# Altium schematic sheet InclinometerPower.SchDoc
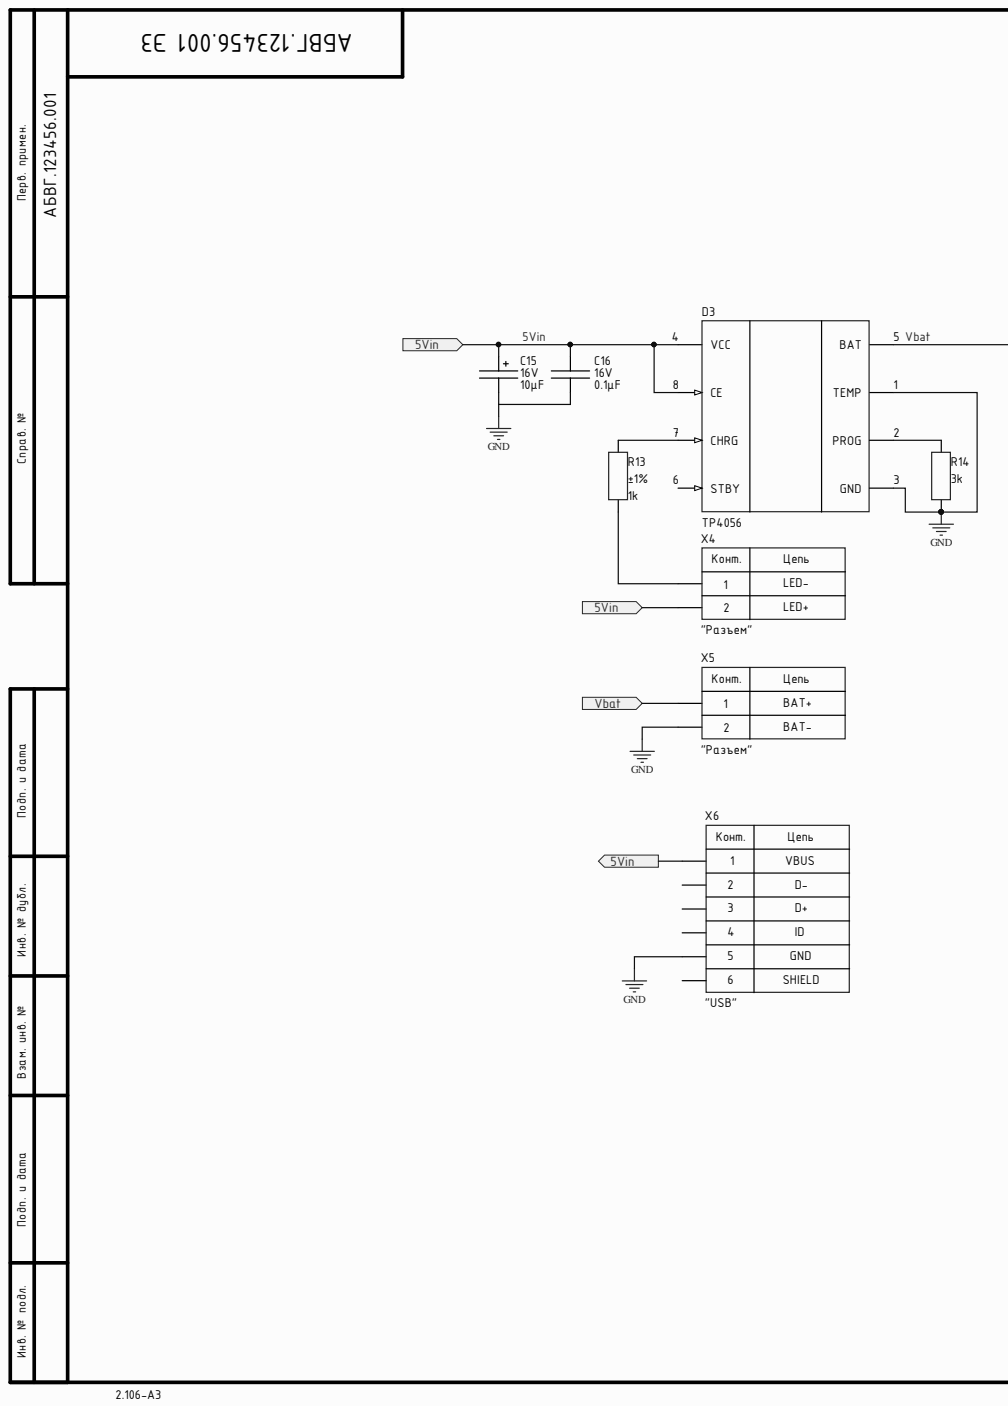
[diagram p0: InclinometerPower.SchDoc, left]
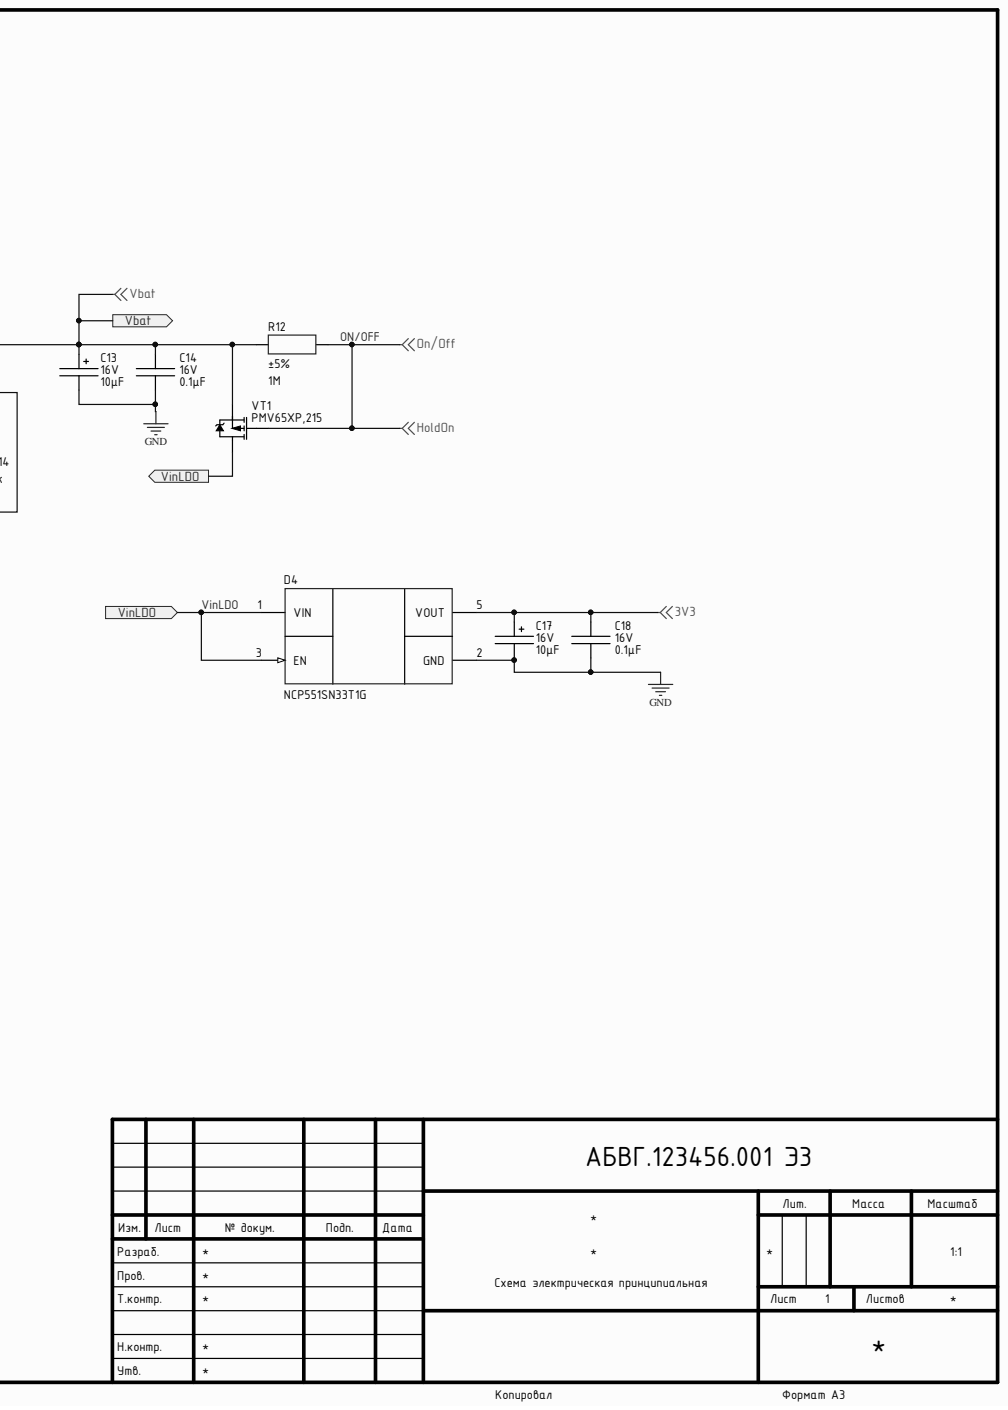
[diagram p1: InclinometerPower.SchDoc, right]

## Parts
C13  value 10μF  Voltage: 16V  [CAP: TANTAL-A]  (hidden comment: Tantalum capacitor, 10μF, 16V, ±10%, 3Ohm, case A (3216), -55...+125°C)
C14  value 0.1μF  Voltage: 16V  [CAP: 0603]  (hidden comment: Ceramic capacitor, 0.1μF, X7R, ±10%, 16V, 0603, -55...+125°C)
C15  value 10μF  Voltage: 16V  [CAP: TANTAL-A]  (hidden comment: Tantalum capacitor, 10μF, 16V, ±10%, 3Ohm, case A (3216), -55...+125°C)
C16  value 0.1μF  Voltage: 16V  [CAP: 0603]  (hidden comment: Ceramic capacitor, 0.1μF, X7R, ±10%, 16V, 0603, -55...+125°C)
C17  value 10μF  Voltage: 16V  [CAP: TANTAL-A]  (hidden comment: Tantalum capacitor, 10μF, 16V, ±10%, 3Ohm, case A (3216), -55...+125°C)
C18  value 0.1μF  Voltage: 16V  [CAP: 0603]  (hidden comment: Ceramic capacitor, 0.1μF, X7R, ±10%, 16V, 0603, -55...+125°C)
D3  Linear Li-lon Battery Charger, VoltageInput, MaxDropout, VoltageOutput, 1A, -40...+85°C, SOP-8  [SOP8]  (value not drawn)
D4  Linear voltage regulator, input 0...12V, 0.25V, output 3.3V, 150mA, -40...+85°C, TSOP-5  [5-TSOP]  (value not drawn)
R12  value 1M  Tolerance: ±5%  [RES: 0603]  (hidden comment: Resistor film, 1M, ±5%, 0603, 0.1W, -55...+155°C)
R13  value 1k  Tolerance: ±1%  [RES: 0603]  (hidden comment: Resistor film, 1k, ±1%, 0603, 0.1W, -55...+155°C)
R14  value 3k  [RES: 0603]  (hidden comment: Resistor film, 3k, ±1%, 0603, 0.1W, -55...+155°C)
VT1  PartNumber: PMV65XP,215  [SOT23]  (hidden comment: MOSFET P-channel transistor, -20V, -2.8A, 7.7nC, 4.16W, 58mOhm, 744pF, SOT23, -55...+150°C)
X4  CommentText: "Разъем"  [CON: PLS-2]  (hidden comment: Connector, 2pin, 2.54mm, 500VAC, 3A)
X5  CommentText: "Разъем"  [CON: PLS-2]  (hidden comment: Connector, 2pin, 2.54mm, 500VAC, 3A)
X6  CommentText: "USB"  [CON: USB micro-A (ZX62-B-5PA)]  (hidden comment: USB micro B)

## Ports
5Vin, Vbat, VinLDO

## Nets
3V3: C17.1 C18.2 D4.5(VOUT)
GND: C13.2 C14.1 C15.2 C16.1 C17.2 C18.1 D3.1(TEMP) D3.3(GND) D4.2(GND) R14.1 X5.2 X6.5
5Vin: C15.1 C16.2 D3.4(VCC) D3.8(CE) X4.2 X6.1 ; port 5Vin
ON/OFF: R12.2 VT1.1
Vbat: C13.1 C14.2 D3.5(BAT) R12.1 VT1.2 X5.1 ; port Vbat
VinLDO: D4.1(VIN) D4.3(EN) VT1.3 ; port VinLDO
NetD3_2: D3.2(PROG) R14.2
NetD3_7: D3.7(CHRG) R13.2
NetR13_1: R13.1 X4.1

Not connected: D3 6(STBY); X6 0 2 3 4

## Library data of the parts
C13 C15 C17 = Tantal_A: Manufacturer: AVX; PartNumber: TAJA106K016RNJ; Component Kind: Standard; HelpURL: https://www.digikey.com/product-detail/en/avx-corporation/TAJA106K016RNJ/478-1655-1-ND/564687; Impedance: 3Ohm; Library Name: Capacitor.IntLib; Package: case A (3216); Temperature: -55...+125°C; Tolerance: ±10%; TypeCapacitor: Tantalum capacitor; ValueManufacturerPartNumber: Tantalum capacitor, 10μF, 16V, ±10%, 3Ohm, case A (3216), -55...+125°C
C14 C16 C18 = Ceramic_0603: Manufacturer: Murata Electronics; PartNumber: GRM188R71C104KA01D; Component Kind: Standard; HelpURL: https://www.digikey.com/product-detail/en/murata-electronics/GRM188R71C104KA01D/490-1532-2-ND/587060; Library Name: Capacitor.IntLib; Package: 0603; Temperature: -55...+125°C; Tolerance: ±10%; TypeCapacitor: Ceramic capacitor; TypeDielectric: X7R; ValueManufacturerPartNumber: Ceramic capacitor, 0.1μF, X7R, ±10%, 16V, 0603, -55...+125°C
D3 = TP4056: Linear Li-lon Battery Charger; Manufacturer: Top Power; Component Kind: Standard; ComponentType: Linear Li-lon Battery Charger; CurrentOutput: 1A; HelpURL: https://www.chipdip.ru/product/tp4056; Library Name: IC. Power supply.IntLib; Package: SOP-8; PartNumber: TP4056; Temperature: -40...+85°C; ValueManufacturerPartNumber: Linear Li-lon Battery Charger, VoltageInput, MaxDropout, VoltageOutput, 1A, -40...+85°C, SOP-8
D4 = NCP551SN33T1G: Linear voltage regulator; Manufacturer: ON Semiconductor; Component Kind: Standard; ComponentType: Linear voltage regulator; CurrentOutput: 150mA; HelpURL: https://www.digikey.com/en/products/detail/onsemi/NCP551SN33T1G/13511773; Library Name: IC. Power supply.IntLib; MaxDropout: 0.25V; Package: TSOP-5; PartNumber: NCP551SN33T1G; Temperature: -40...+85°C; ValueManufacturerPartNumber: Linear voltage regulator, input 0...12V, 0.25V, output 3.3V, 150mA, -40...+85°C, TSOP-5; VoltageInput: input 0...12V; VoltageOutput: output 3.3V
R12 = Resistor_0603: Manufacturer: Yageo; PartNumber: RC0603JR-071ML; Component Kind: Standard; HelpURL: https://www.digikey.com/product-detail/en/yageo/RC0603FR-071KL/311-1-00KHRCT-ND/729790; Library Name: Resistor.IntLib; Package: 0603; Power: 0.1W; Temperature: -55...+155°C; TypeResistor: Resistor film; Value: 1M; ValueManufacturerPartNumber: Resistor film, 1M, ±5%, 0603, 0.1W, -55...+155°C
R13 = Resistor_0603: Manufacturer: Yageo; PartNumber: RC0603FR-071KL; Component Kind: Standard; HelpURL: https://www.digikey.com/product-detail/en/yageo/RC0603FR-071KL/311-1-00KHRCT-ND/729790; Library Name: Resistor.IntLib; Package: 0603; Power: 0.1W; Temperature: -55...+155°C; TypeResistor: Resistor film; ValueManufacturerPartNumber: Resistor film, 1k, ±1%, 0603, 0.1W, -55...+155°C
R14 = Resistor_0603: Manufacturer: Yageo; PartNumber: RC0603FR-073KL; Component Kind: Standard; HelpURL: https://www.digikey.com/product-detail/en/yageo/RC0603FR-071KL/311-1-00KHRCT-ND/729790; Library Name: Resistor.IntLib; Package: 0603; Power: 0.1W; Temperature: -55...+155°C; Tolerance: ±1%; TypeResistor: Resistor film; ValueManufacturerPartNumber: Resistor film, 3k, ±1%, 0603, 0.1W, -55...+155°C
VT1 = MOSFET_P_PMV65XP: Manufacturer: Nexperia; Component Kind: Standard; CurrentDS: -2.8A; GateCharge: 7.7nC; HelpURL: https://www.digikey.com/en/products/detail/nexperia-usa-inc/PMV65XP215/949643; InputCapacitor: 744pF; Library Name: Transistor.IntLib; MaxPower: 4.16W; Package: SOT23; RDS: 58mOhm; Temperature: -55...+150°C; TypeTransistor: MOSFET P-channel transistor; ValueManufacturerPartNumber: MOSFET P-channel transistor, -20V, -2.8A, 7.7nC, 4.16W, 58mOhm, 744pF, SOT23, -55...+150°C; VoltageDS: -20V
X4 X5 = PLS-2: Manufacturer: Connfly; Component Kind: Standard; CurrentMax: 3A; HelpURL: https://www.chipdip.ru/product/pls-2; Library Name: Connector.IntLib; Multichannel: 2pin; PartNumber: PLS-2; Pitch: 2.54mm; TypeConnector: Connector; ValueManufacturerPartNumber: Connector, 2pin, 2.54mm, 500VAC, 3A; VoltageMax: 500VAC
X6 = Connector_USB_micro_B: Manufacturer: Hirose Electric; PartNumber: ZX62-B-5PA; Component Kind: Standard; HelpURL: https://www.digikey.com/product-detail/en/hirose-electric-co-ltd/ZX62-B-5PA/H11579CT-ND/1851090; Library Name: Connector.IntLib; TypeConnector: USB micro B; ValueManufacturerPartNumber: USB micro B
